# Altium schematic sheet Condicionamento2.SchDoc
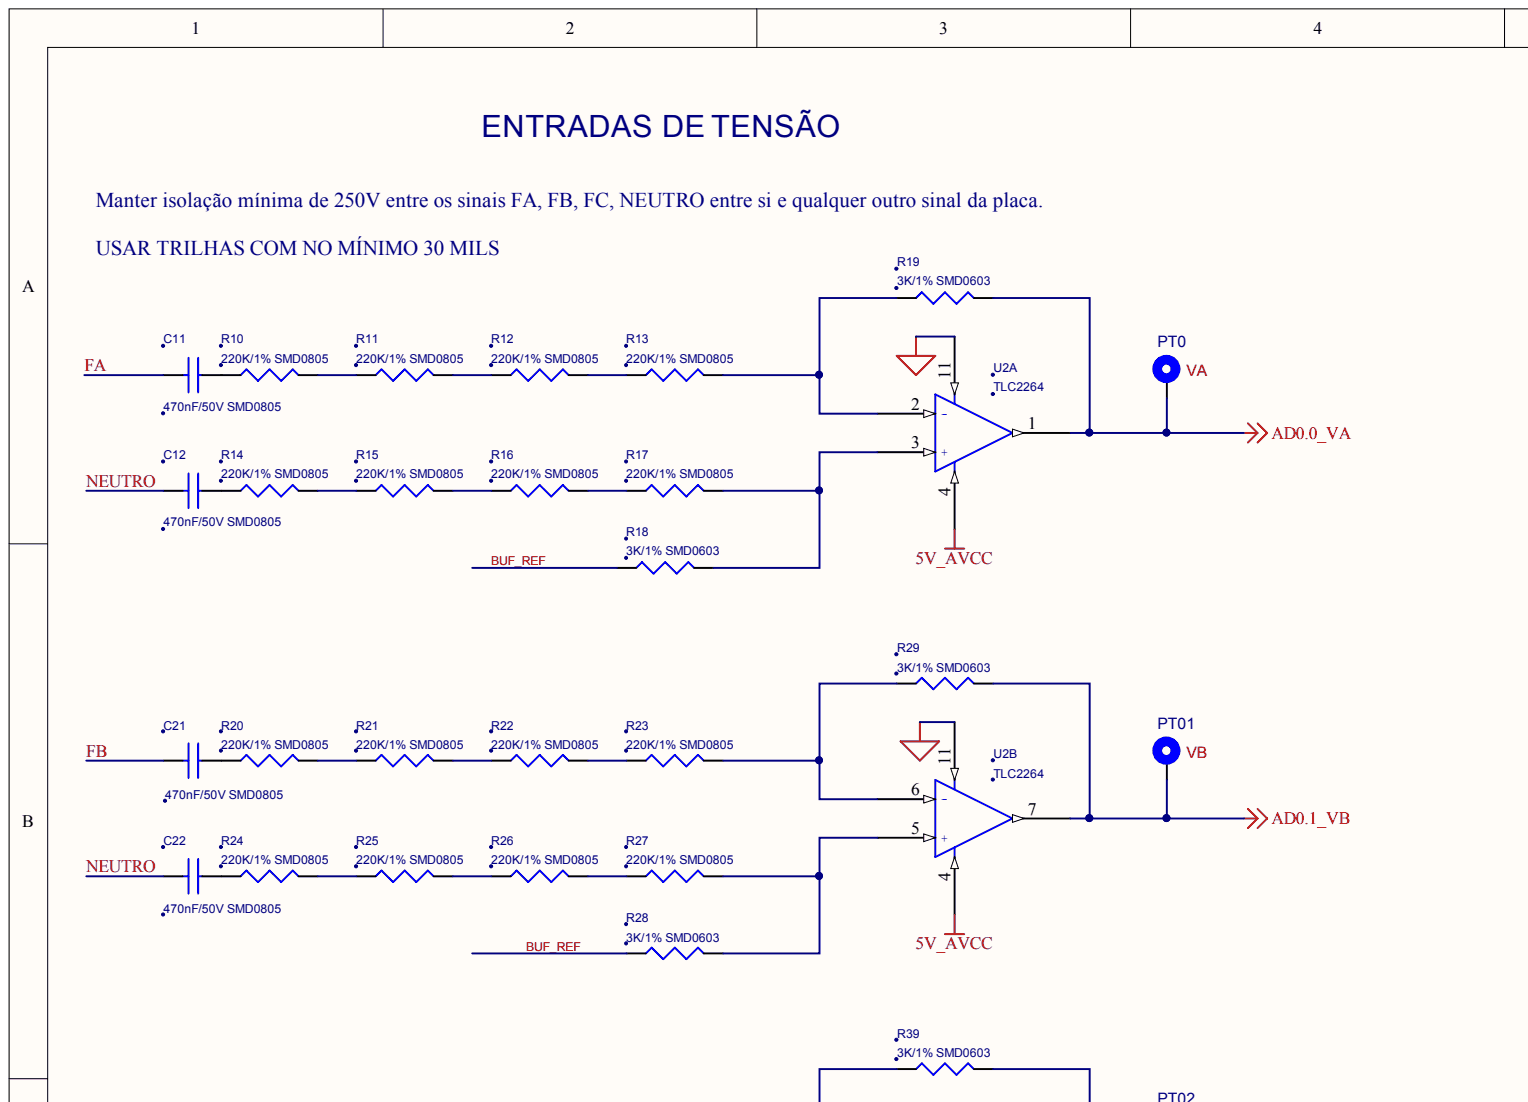
[diagram p0: Condicionamento2.SchDoc, top left]
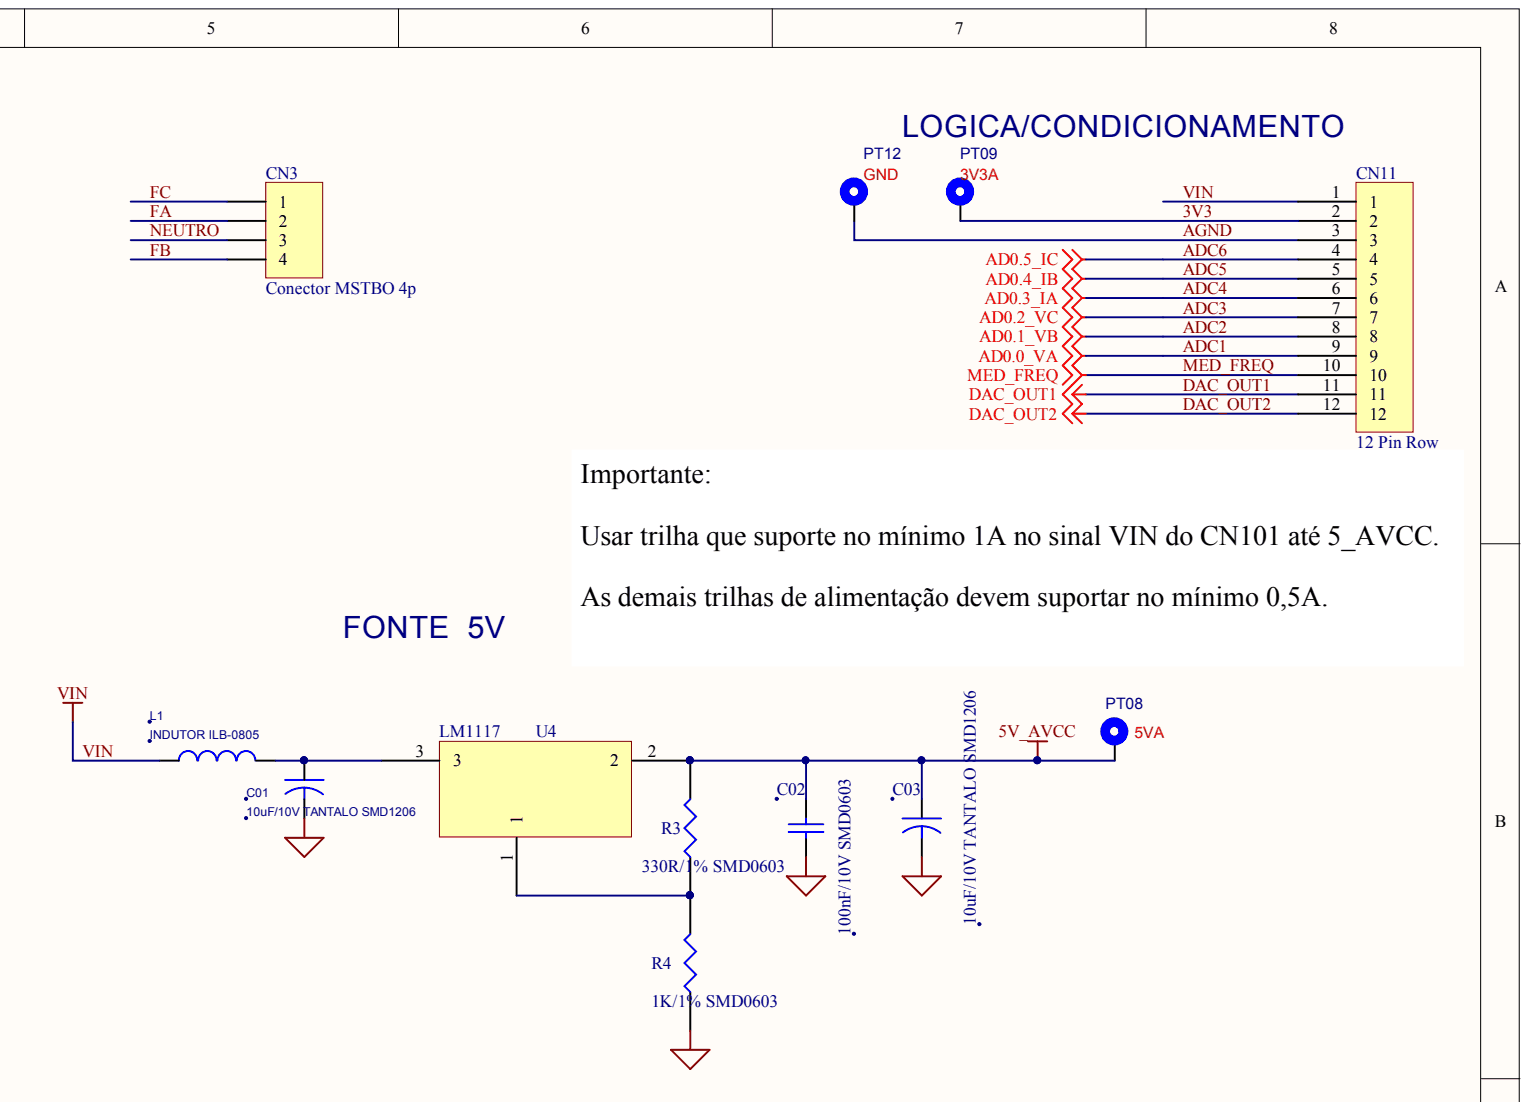
[diagram p1: Condicionamento2.SchDoc, top right]
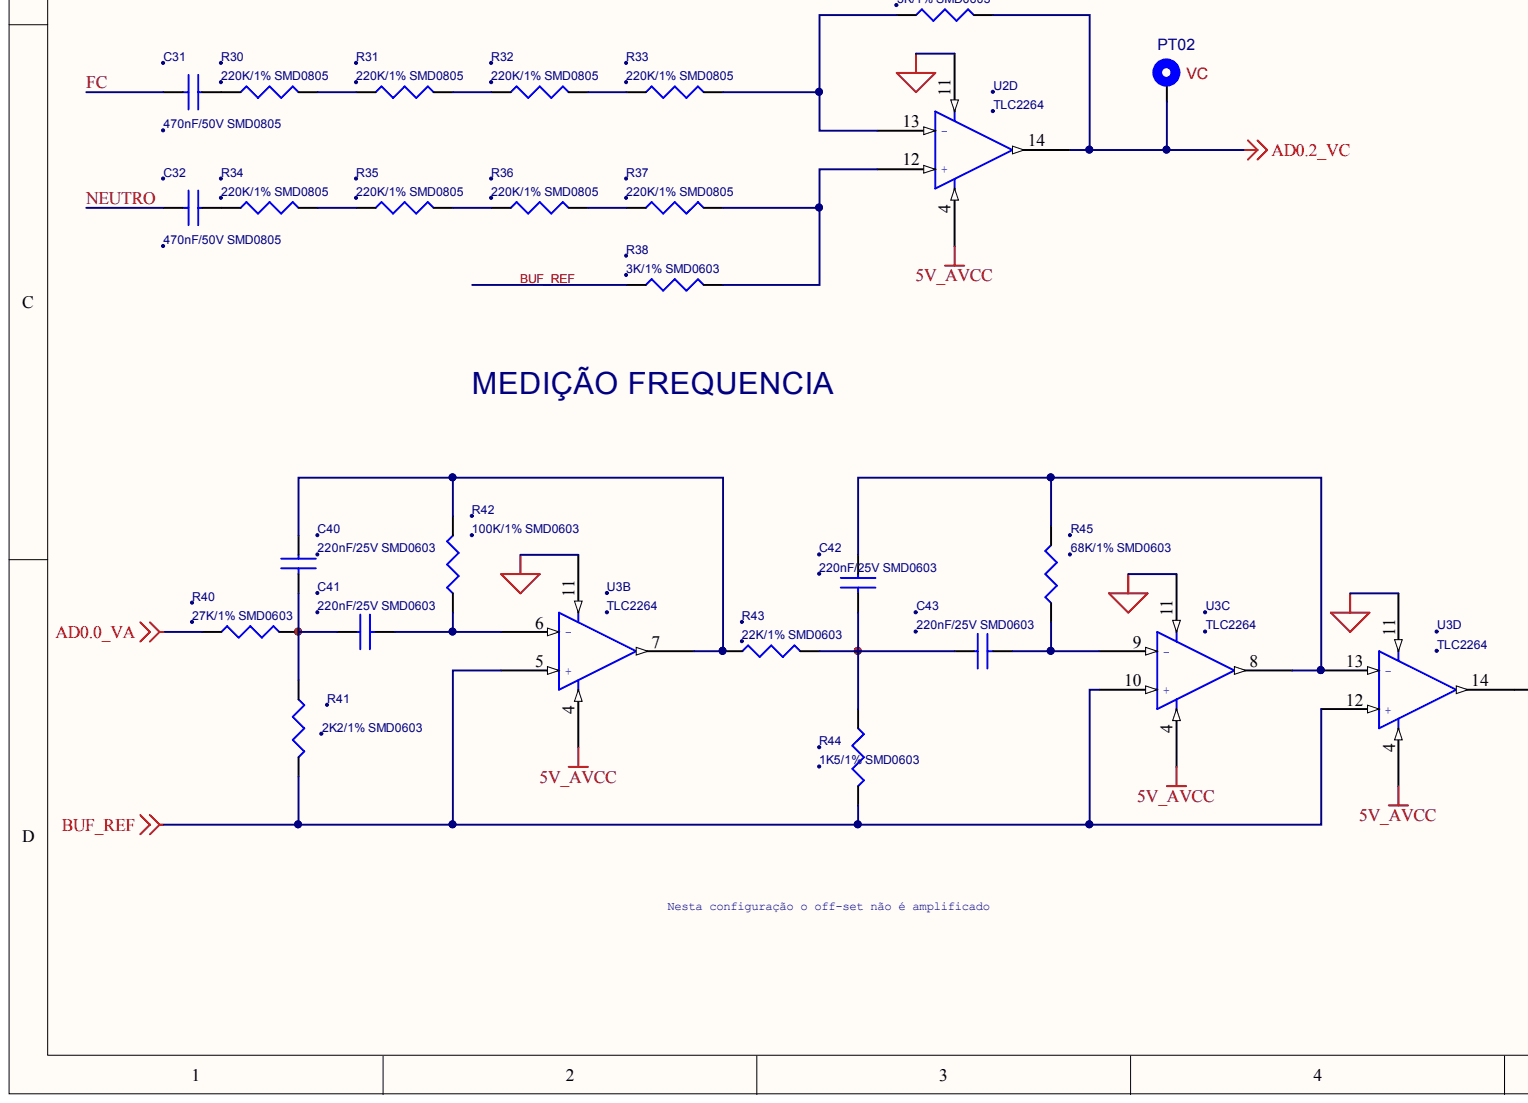
[diagram p2: Condicionamento2.SchDoc, bottom left]
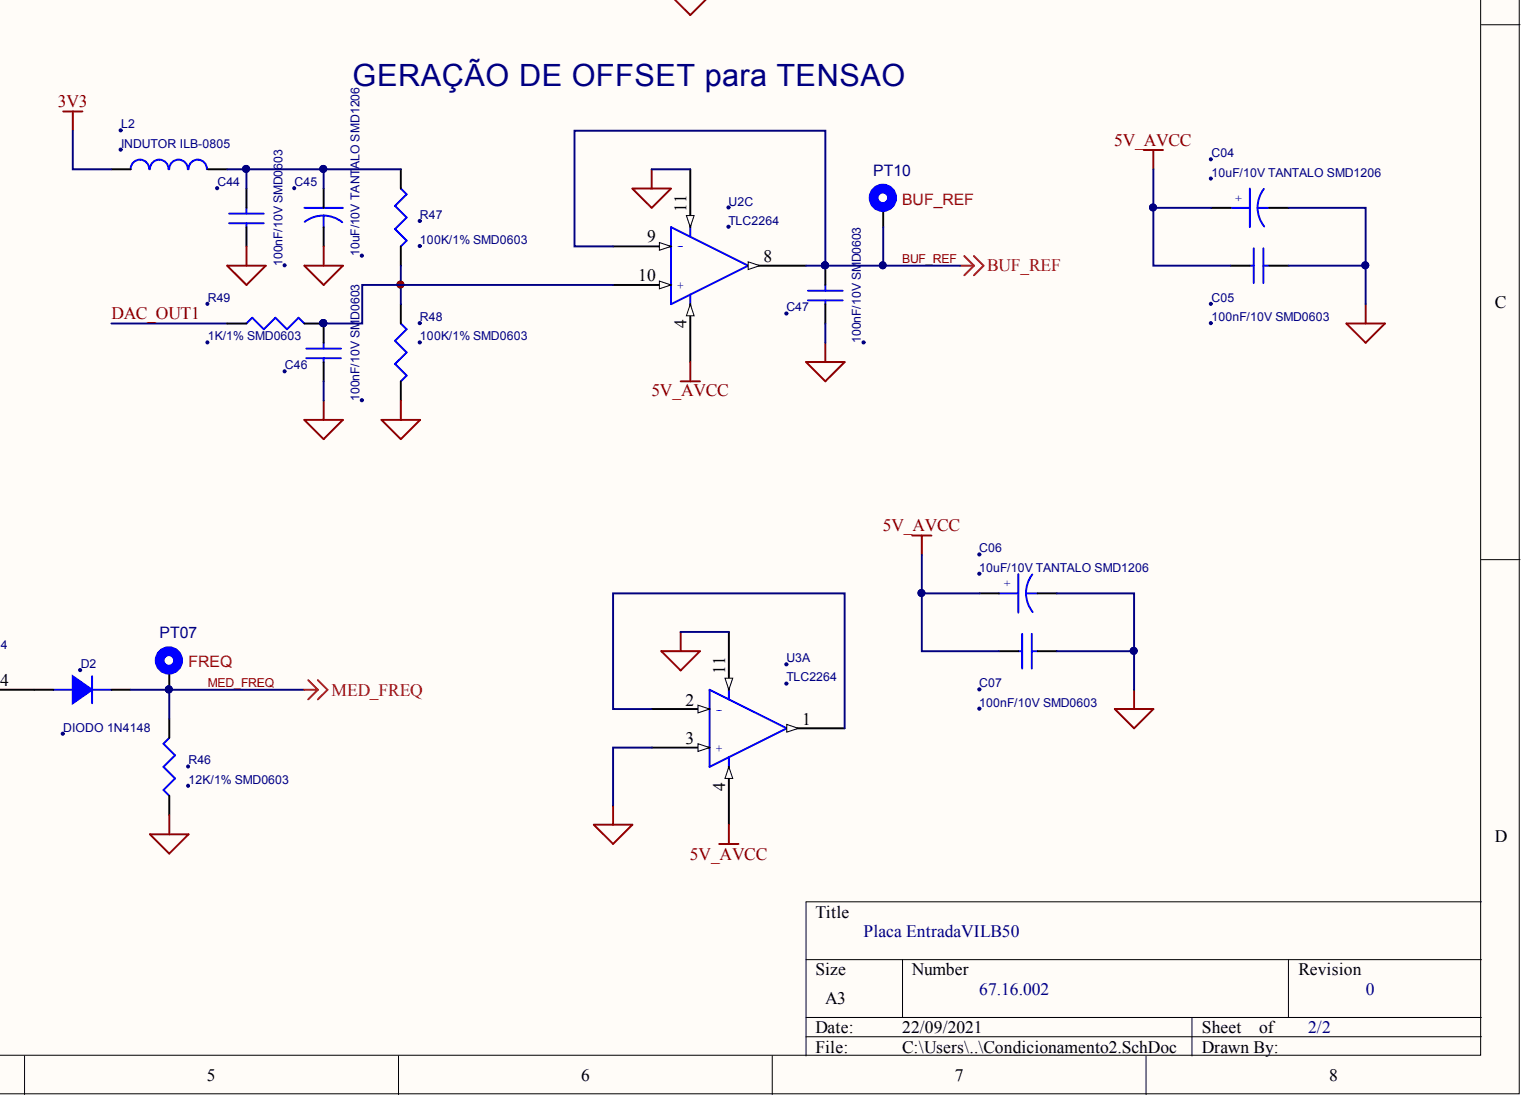
[diagram p3: Condicionamento2.SchDoc, bottom right]

## Parts
C01  10uF/10V TANTALO SMD1206  [TANA]
C02  100nF/10V SMD0603  [0603]
C03  10uF/10V TANTALO SMD1206  [TANA]
C04  10uF/10V TANTALO SMD1206  [TANA]
C05  100nF/10V SMD0603  [0603]
C06  10uF/10V TANTALO SMD1206  [TANA]
C07  100nF/10V SMD0603  [0603]
C11  470nF/50V SMD0805  [0805]
C12  470nF/50V SMD0805  [0805]
C21  470nF/50V SMD0805  [0805]
C22  470nF/50V SMD0805  [0805]
C31  470nF/50V SMD0805  [0805]
C32  470nF/50V SMD0805  [0805]
C40  220nF/25V SMD0603  [0603]
C41  220nF/25V SMD0603  [0603]
C42  220nF/25V SMD0603  [0603]
C43  220nF/25V SMD0603  [0603]
C44  100nF/10V SMD0603  [0603]
C45  10uF/10V TANTALO SMD1206  [TANA]
C46  100nF/10V SMD0603  [0603]
C47  100nF/10V SMD0603  [0603]
CN3  Conector MSTBO 4p  [MSTBO-ESQ]
CN11  12 Pin Row  [12 Pin Row]
D2  DIODO 1N4148  [SOD123A]
L1  INDUTOR ILB-0805  [BLM21]
L2  INDUTOR ILB-0805  [BLM21]
PT0  VA  [PTR31]
PT01  VB  [PTR31]
PT02  VC  [PTR31]
PT07  FREQ  [PTR31]
PT08  5VA  [PTR31]
PT09  3V3A  [PTR31]
PT10  BUF_REF  [PTR31]
PT12  GND  [PTR31]
R3  330R/1% SMD0603  [0603]
R4  1K/1% SMD0603  [0603]
R10  220K/1% SMD0805  [0805]
R11  220K/1% SMD0805  [0805]
R12  220K/1% SMD0805  [0805]
R13  220K/1% SMD0805  [0805]
R14  220K/1% SMD0805  [0805]
R15  220K/1% SMD0805  [0805]
R16  220K/1% SMD0805  [0805]
R17  220K/1% SMD0805  [0805]
R18  3K/1% SMD0603  [0603]
R19  3K/1% SMD0603  [0603]
R20  220K/1% SMD0805  [0805]
R21  220K/1% SMD0805  [0805]
R22  220K/1% SMD0805  [0805]
R23  220K/1% SMD0805  [0805]
R24  220K/1% SMD0805  [0805]
R25  220K/1% SMD0805  [0805]
R26  220K/1% SMD0805  [0805]
R27  220K/1% SMD0805  [0805]
R28  3K/1% SMD0603  [0603]
R29  3K/1% SMD0603  [0603]
R30  220K/1% SMD0805  [0805]
R31  220K/1% SMD0805  [0805]
R32  220K/1% SMD0805  [0805]
R33  220K/1% SMD0805  [0805]
R34  220K/1% SMD0805  [0805]
R35  220K/1% SMD0805  [0805]
R36  220K/1% SMD0805  [0805]
R37  220K/1% SMD0805  [0805]
R38  3K/1% SMD0603  [0603]
R39  3K/1% SMD0603  [0603]
R40  27K/1% SMD0603  [0603]
R41  2K2/1% SMD0603  [0603]
R42  100K/1% SMD0603  [0603]
R43  22K/1% SMD0603  [0603]
R44  1K5/1% SMD0603  [0603]
R45  68K/1% SMD0603  [0603]
R46  12K/1% SMD0603  [0603]
R47  100K/1% SMD0603  [0603]
R48  100K/1% SMD0603  [0603]
R49  1K/1% SMD0603  [0603]
U2  TLC2264  [SOIC14]  (parts A,B,C,D)
U3  TLC2264  (parts A,B,C,D)
U4  LM1117  [SOT223G]

## Nets
5V_AVCC: C02.1 C03.1 C04.1 C05.1 C06.1 C07.1 PT08.1 R3.2 U2.4 U3.4 U4.2
3V3: CN11.2 L2.1 PT09.1
ADC1: CN11.9 PT0.1 R19.2 R40.1 U2.1
ADC2: CN11.8 PT01.1 R29.2 U2.7
ADC3: CN11.7 PT02.1 R39.2 U2.14
ADC4: CN11.6
ADC5: CN11.5
ADC6: CN11.4
AGND: C01.2 C02.2 C03.2 C04.2 C05.2 C06.2 C07.2 C44.1 C45.2 C46.1 C47.1 CN11.3 PT12.1 R4.1 R46.1 R48.2 U2.11 U3.3(+) U3.11
BUF_REF: C47.2 PT10.1 R18.1 R28.1 R38.1 R41.2 R44.2 U2.8 U2.9 U3.5(+) U3.10(+) U3.12(+)
DAC_OUT1: CN11.11 R49.1
DAC_OUT2: CN11.12
FA: C11.2 CN3.2
FB: C21.2 CN3.4
FC: C31.2 CN3.1
MED_FREQ: CN11.10 D2.2 PT07.1 R46.2
NEUTRO: C12.2 C22.2 C32.2 CN3.3
VIN: CN11.1 L1.1
NetC01_1: C01.1 L1.2 U4.3
NetC11_1: C11.1 R10.1
NetC12_1: C12.1 R14.1
NetC21_1: C21.1 R20.1
NetC22_1: C22.1 R24.1
NetC31_1: C31.1 R30.1
NetC32_1: C32.1 R34.1
NetC40_1: C40.1 R42.2 R43.1 U3.7
NetC40_2: C40.2 C41.1 R40.2 R41.1
NetC41_2: C41.2 R42.1 U3.6(-)
NetC42_1: C42.1 R45.2 U3.8 U3.13(-)
NetC42_2: C42.2 C43.1 R43.2 R44.1
NetC43_2: C43.2 R45.1 U3.9(-)
NetC44_2: C44.2 C45.1 L2.2 R47.1
NetC46_2: C46.2 R47.2 R48.1 R49.2 U2.10
NetD2_1: D2.1 U3.14
NetR3_1: R3.1 R4.2 U4.1
NetR10_2: R10.2 R11.1
NetR11_2: R11.2 R12.1
NetR12_2: R12.2 R13.1
NetR13_2: R13.2 R19.1 U2.2(-)
NetR14_2: R14.2 R15.1
NetR15_2: R15.2 R16.1
NetR16_2: R16.2 R17.1
NetR17_2: R17.2 R18.2 U2.3(+)
NetR20_2: R20.2 R21.1
NetR21_2: R21.2 R22.1
NetR22_2: R22.2 R23.1
NetR23_2: R23.2 R29.1 U2.6(-)
NetR24_2: R24.2 R25.1
NetR25_2: R25.2 R26.1
NetR26_2: R26.2 R27.1
NetR27_2: R27.2 R28.2 U2.5(+)
NetR30_2: R30.2 R31.1
NetR31_2: R31.2 R32.1
NetR32_2: R32.2 R33.1
NetR33_2: R33.2 R39.1 U2.13(-)
NetR34_2: R34.2 R35.1
NetR35_2: R35.2 R36.1
NetR36_2: R36.2 R37.1
NetR37_2: R37.2 R38.2 U2.12(+)
NetU3_1: U3.1 U3.2(-)

## Library data of the parts
C01 C45 = C: Component Kind: Standard
C02 = CAP: Capacitor; Component Kind: Standard
C03 = CAPACITOR: : SMD 1206; Component Kind: Standard; Description: Capacitor
C04 C06 = CAPACITOR POL_0: Component Kind: Standard
C05 C07 C11 C12 C21 C22 C31 C32 C40-C44 C46 C47 = CAP NP: Component Kind: Standard
D2 = DIODE: Component Kind: Standard
L1 L2 = INDUCTOR: Component Kind: Standard
PT0 PT01 PT02 PT07 PT08 PT09 PT10 PT12 = AUX_PT: PONTO DE TESTE SMD; Component Kind: Standard; Library Name: AUXILIAR_SYM.LIB
R3 R4 = RES1: Component Kind: Standard
R10-R17 R20-R27 R30-R37 R47-R49 = R_8: Component Kind: Standard
R18 R19 R28 R29 R38 R39 = R: Component Kind: Standard
R40-R46 = R_Dup1: Component Kind: Standard
U2 U3 = LM324: Component Kind: Standard
U4 = LM 2574 - 5V: Text Field1: 100; Text Field2: 50; Component Kind: Standard
CN3 = Conector MSTBO 4p: Component Kind: Standard; Library Name: md30e-1.SCHLIB
CN11 = 12 Pin Row: Component Kind: Standard; Library Name: SCH - CONNECTORS - 12 PIN.SchLib
